# Altium schematic sheet RP2040.SchDoc(RP2040)
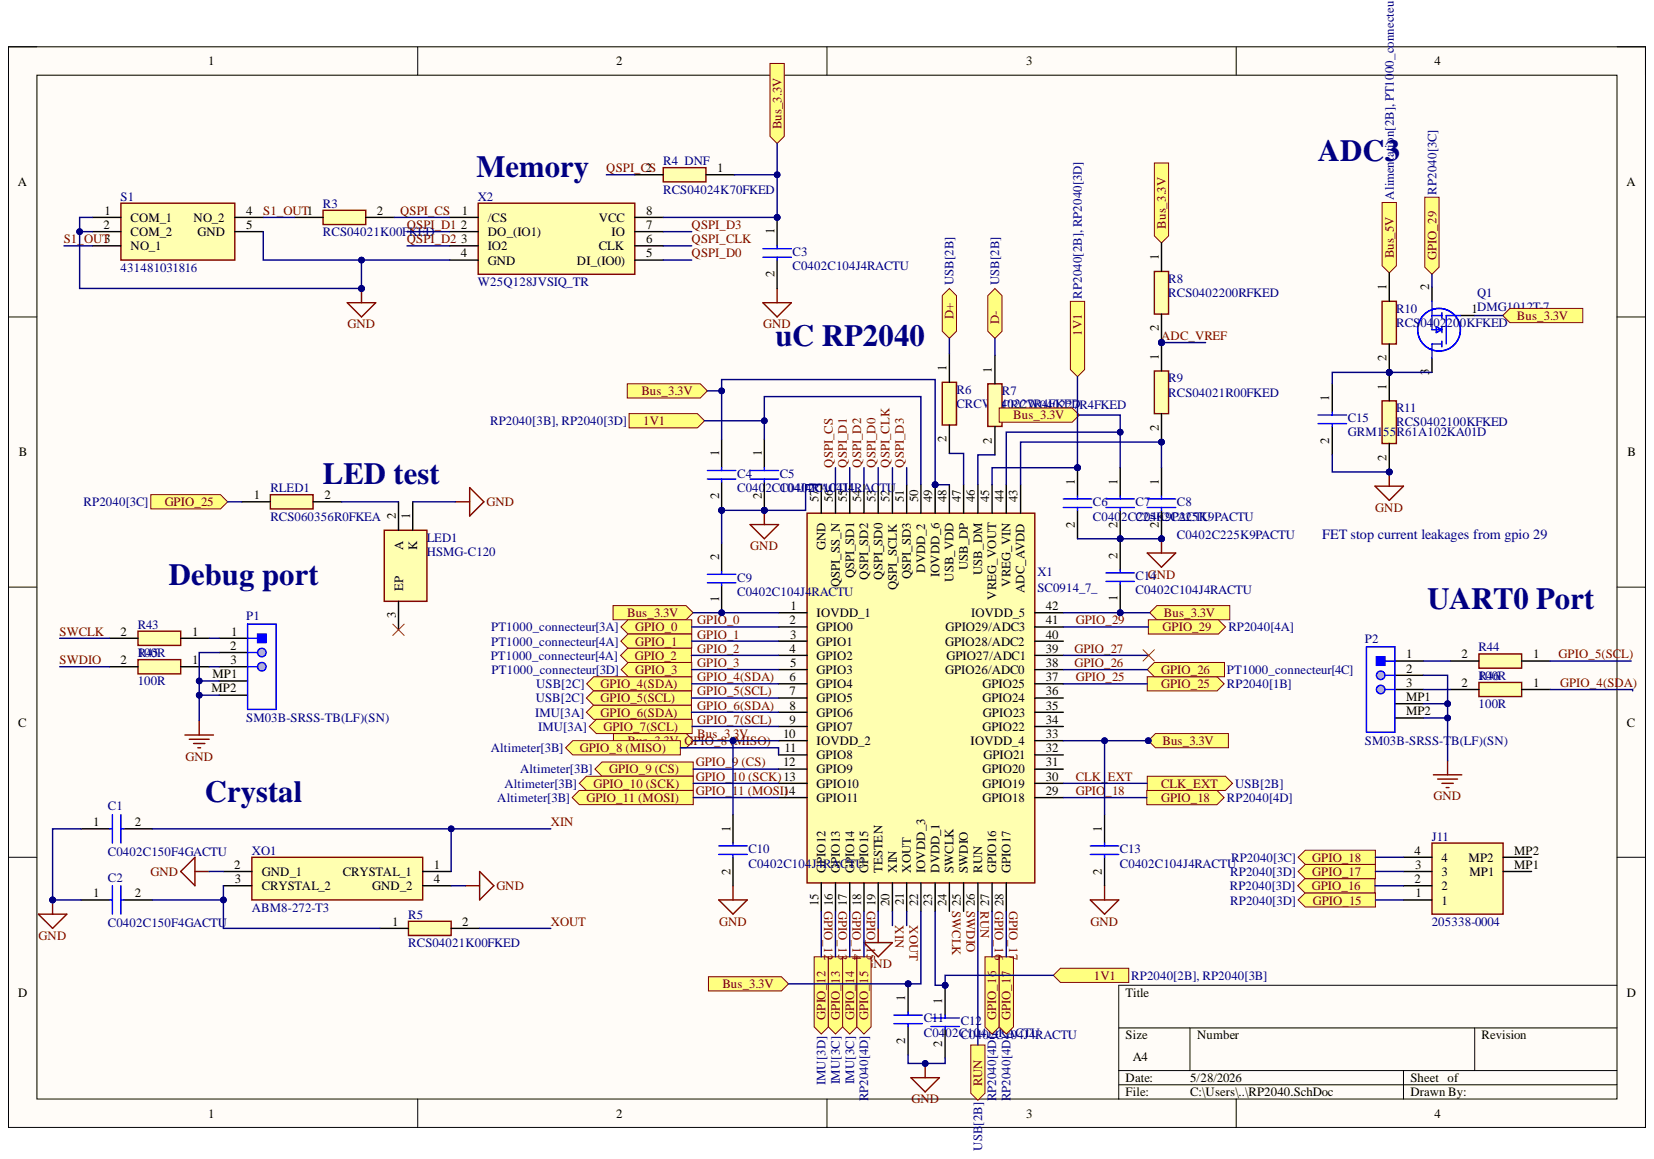
[diagram p0: RP2040.SchDoc]

## Parts
C1  C0402C150F4GACTU  [C0402C104J4RACTU]
C2  C0402C150F4GACTU  [C0402C104J4RACTU]
C3  C0402C104J4RACTU  [C0402C104J4RACTU]
C4  C0402C104J4RACTU  [C0402C104J4RACTU]
C5  C0402C104J4RACTU  [C0402C104J4RACTU]
C6  C0402C225K9PACTU  [C0402C104J4RACTU]
C7  C0402C225K9PACTU  [C0402C104J4RACTU]
C8  C0402C225K9PACTU  [C0402C104J4RACTU]
C9  C0402C104J4RACTU  [C0402C104J4RACTU]
C10  C0402C104J4RACTU  [C0402C104J4RACTU]
C11  C0402C104J4RACTU  [C0402C104J4RACTU]
C12  C0402C104J4RACTU  [C0402C104J4RACTU]
C13  C0402C104J4RACTU  [C0402C104J4RACTU]
C14  C0402C104J4RACTU  [C0402C104J4RACTU]
C15  GRM155R61A102KA01D  [CAPC1005X70N]
J11  205338-0004  [2053380004]
LED1  HSMG-C120  [HSMGC120]
P1  SM03B-SRSS-TB(LF)(SN)  [JST-SM03B-SRSS-TB_V]
P2  SM03B-SRSS-TB(LF)(SN)  [JST-SM03B-SRSS-TB_V]
Q1  DMG1012T-7  [SOT50P160X90-3N]
R3  RCS04021K00FKED  [RCS0402_E3]
R4  RCS04024K70FKED  [RCS0402_E3]
R5  RCS04021K00FKED  [RCS0402_E3]
R6  CRCW040227R4FKED  [RESC1005X40N]
R7  CRCW040227R4FKED  [RESC1005X40N]
R8  RCS0402200RFKED  [RCS0402_E3]
R9  RCS04021R00FKED  [RCS0402_E3]
R10  RCS0402200KFKED  [RCS0402_E3]
R11  RCS0402100KFKED  [RCS0402_E3]
R43  100R  [RCS0402_E3]
R44  100R  [RCS0402_E3]
R45  100R  [RCS0402_E3]
R46  100R  [RCS0402_E3]
RLED1  RCS060356R0FKEA  [RCS0603_E3]
S1  431481031816  [431481031816]
X1  SC0914_7_  [QFN40P700X700X90-57N-D]
X2  W25Q128JVSIQ_TR  [SOIC127P780X195-8N]
XO1  ABM8-272-T3  [ABM8272T3]

## Ports
1V1, Bus_3.3V, Bus_5V, CLK_EXT, D+, D-, GPIO_0, GPIO_1, GPIO_2, GPIO_3, GPIO_4(SDA), GPIO_5(SCL), GPIO_6(SDA), GPIO_7(SCL), GPIO_8 (MISO), GPIO_9 (CS), GPIO_10 (SCK), GPIO_11 (MOSI), GPIO_12, GPIO_13, GPIO_14, GPIO_15, GPIO_16, GPIO_17, GPIO_18, GPIO_25, GPIO_26, GPIO_29, RUN

## Nets
GND: C1.1 C2.1 C3.2 C4.2 C5.2 C6.2 C7.2 C8.2 C9.2 C10.2 C11.2 C12.2 C13.2 C14.2 C15.2 LED1.1 P1.2 P1.MP1 P1.MP2 P2.2 P2.MP1 P2.MP2 R11.2 S1.1(COM_1) S1.2(COM_2) S1.5(GND) X1.19(TESTEN) X1.57(GND) X2.4(GND) XO1.2(GND_1) XO1.4(GND_2)
1V1: C5.1 C6.1 C12.1 X1.23(DVDD_1) X1.45(VREG_VOUT) X1.50(DVDD_2) ; port 1V1
ADC_VREF: R8.2 R9.1
Bus_3.3V: C3.1 C4.1 C7.1 C9.1 C10.1 C11.1 C13.1 C14.1 Q1.1 R4.1 R8.1 X1.1(IOVDD_1) X1.10(IOVDD_2) X1.22(IOVDD_3) X1.33(IOVDD_4) X1.42(IOVDD_5) X1.44(VREG_VIN) X1.48(USB_VDD) X1.49(IOVDD_6) X2.8(VCC) ; port Bus_3.3V
Bus_5V: R10.1 ; port Bus_5V
CLK_EXT: X1.30(GPIO19) ; port CLK_EXT
GPIO_0: X1.2(GPIO0) ; port GPIO_0
GPIO_1: X1.3(GPIO1) ; port GPIO_1
GPIO_2: X1.4(GPIO2) ; port GPIO_2
GPIO_3: X1.5(GPIO3) ; port GPIO_3
GPIO_4(SDA): R46.1 X1.6(GPIO4) ; port GPIO_4(SDA)
GPIO_5(SCL): R44.1 X1.7(GPIO5) ; port GPIO_5(SCL)
GPIO_6(SDA): X1.8(GPIO6) ; port GPIO_6(SDA)
GPIO_7(SCL): X1.9(GPIO7) ; port GPIO_7(SCL)
GPIO_8 (MISO): X1.11(GPIO8) ; port GPIO_8 (MISO)
GPIO_9 (CS): X1.12(GPIO9) ; port GPIO_9 (CS)
GPIO_10 (SCK): X1.13(GPIO10) ; port GPIO_10 (SCK)
GPIO_11 (MOSI): X1.14(GPIO11) ; port GPIO_11 (MOSI)
GPIO_12: X1.15(GPIO12) ; port GPIO_12
GPIO_13: X1.16(GPIO13) ; port GPIO_13
GPIO_14: X1.17(GPIO14) ; port GPIO_14
GPIO_15: J11.1 X1.18(GPIO15) ; port GPIO_15
GPIO_16: J11.2 X1.27(GPIO16) ; port GPIO_16
GPIO_17: J11.3 X1.28(GPIO17) ; port GPIO_17
GPIO_18: J11.4 X1.29(GPIO18) ; port GPIO_18
GPIO_25: RLED1.1 X1.37(GPIO25) ; port GPIO_25
GPIO_26: X1.38(GPIO26/ADC0) ; port GPIO_26
GPIO_27: X1.39(GPIO27/ADC1)
GPIO_29: Q1.2 X1.41(GPIO29/ADC3) ; port GPIO_29
QSPI_CLK: X1.52(QSPI_SCLK) X2.6(CLK)
QSPI_CS: R3.2 R4.2 X1.56(QSPI_SS_N) X2.1(/CS)
QSPI_D0: X1.53(QSPI_SD0) X2.5(DI_(IO0))
QSPI_D1: X1.55(QSPI_SD1) X2.2(DO_(IO1))
QSPI_D2: X1.54(QSPI_SD2) X2.3(IO2)
QSPI_D3: X1.51(QSPI_SD3) X2.7(IO)
RUN: X1.26(RUN) ; port RUN
S1_OUT: R3.1 S1.3(NO_1) S1.4(NO_2)
SWCLK: R43.2 X1.24(SWCLK)
SWDIO: R45.2 X1.25(SWDIO)
XIN: C1.2 X1.20(XIN) XO1.1(CRYSTAL_1)
XOUT: R5.2 X1.21(XOUT)
NetC2_2: C2.2 R5.1 XO1.3(CRYSTAL_2)
NetC8_1: C8.1 R9.2 X1.43(ADC_AVDD)
NetC15_1: C15.1 Q1.3 R10.2 R11.1
NetLED1_2: LED1.2 RLED1.2
NetP1_1: P1.1 R43.1
NetP1_3: P1.3 R45.1
NetP2_1: P2.1 R44.2
NetP2_3: P2.3 R46.2
NetR6_1: R6.1
NetR6_2: R6.2 X1.47(USB_DP)
NetR7_1: R7.1
NetR7_2: R7.2 X1.46(USB_DM)

Not connected: J11 MP1 MP2; LED1 3; X1 31(GPIO20) 32(GPIO21) 34(GPIO22) 35(GPIO23) 36(GPIO24) 40(GPIO28/ADC2)

## Library data of the parts
C1 C2 = C0402C150F4GACTU: Capacitor; Manufacturer_Name: KEMET; Component Kind: Standard; Datasheet Link: https://content.kemet.com/datasheets/KEM_C1002_X7R_SMD.pdf; Height: 0.55mm; Manufacturer_Part_Number: C0402C150F4GACTU; Library Name: ADSC_V2.IntLib
C3-C14 = C0402C104J4RACTU: Condensateur 100nF; Manufacturer_Name: KEMET; Arrow Part Number: C0402C104J4RACTU; Arrow Price/Stock: https://www.arrow.com/en/products/c0402c104j4ractu/kemet-corporation?utm_currency=USD&region=nac; Component Kind: Standard; Datasheet Link: https://content.kemet.com/datasheets/KEM_C1002_X7R_SMD.pdf; Height: 0.55mm; Manufacturer_Part_Number: C0402C104J4RACTU; Mouser Part Number: 80-C0402C104J4R; Mouser Price/Stock: https://www.mouser.co.uk/ProductDetail/KEMET/C0402C104J4RACTU?qs=hOC58ESJ5%2FTi6zdFDTVLPg%3D%3D; Library Name: ADSC_V2.IntLib
C15 = GRM155R61A102KA01D: Capacitor; Manufacturer_Name: Murata Electronics; Arrow Part Number: GRM155R61A102KA01D; Arrow Price/Stock: https://www.arrow.com/en/products/grm155r61a102ka01d/murata-manufacturing?region=nac; Component Kind: Standard; Datasheet Link: https://search.murata.co.jp/Ceramy/image/img/A01X/G101/ENG/GRM155R61A102KA01-01A.pdf; Height: 0.7mm; Manufacturer_Part_Number: GRM155R61A102KA01D; Mouser Part Number: 81-GRM155R61A102KA1D; Mouser Price/Stock: https://www.mouser.co.uk/ProductDetail/Murata-Electronics/GRM155R61A102KA01D?qs=y02iAgv9n635dgph15ru9A%3D%3D; Library Name: ADSC_V2.IntLib
J11 = 205338-0004: Connector; Manufacturer_Name: Molex; Component Kind: Standard; Datasheet Link: https://www.molex.com/pdm_docs/sd/2053380004_sd.pdf; Height: 2.8mm; Manufacturer_Part_Number: 205338-0004; Mouser Part Number: 538-205338-0004; Mouser Price/Stock: https://www.mouser.co.uk/ProductDetail/Molex/205338-0004?qs=GedFDFLaBXGldxOQTm%2FukQ%3D%3D; Library Name: ADSC_V2.IntLib
LED1 = HSMG-C120: LED; Manufacturer_Name: Avago Technologies; Component Kind: Standard; Datasheet Link: https://docs.broadcom.com/docs/AV02-0551EN; Height: 0.7mm; Manufacturer_Part_Number: HSMG-C120; Mouser Part Number: 630-HSMG-C120; Mouser Price/Stock: https://www.mouser.co.uk/ProductDetail/Broadcom-Avago/HSMG-C120?qs=YDL0qNrpDT6Egvnvl3TReA%3D%3D; Library Name: ADSC_V2.IntLib
P1 P2 = CMP-2000-07077-1: Male Header, Pitch 1 mm, 1 x 3 Position, Height 2.9 mm, -25 to 85 degC, RoHS, Tape and Reel; Manufacturer: JST; No of Positions: 3; Pitch: 1mm; Style/Orientation: RightAngleHeader/Plug; Component Kind: Standard; Datasheet URL: https://datasheet.ciiva.com/26894/getdatasheetpartid-708885-26894671.pdf; Datasheet Version: 11/2010; Library Name: Altium Content Vault; Manufacturer URL: http://www.jst.com/home2.html; Mounting Technology: SurfaceMount; Package Description: Male Header, Pitch 1 mm, 1 x 3 Position, Height 2.9 mm; Package Reference: SM03B-SRSS-TB; Termination: Crimp; Type: ShroudedHeader
Q1 = DMG1012T-7: MOSFET (N-Channel); Manufacturer_Name: Diodes Incorporated; Arrow Part Number: DMG1012T-7; Arrow Price/Stock: https://www.arrow.com/en/products/dmg1012t-7/diodes-incorporated?utm_currency=USD&region=nac; Component Kind: Standard; Datasheet Link: https://www.diodes.com//assets/Datasheets/DMG1012T.pdf; Height: 0.9mm; Manufacturer_Part_Number: DMG1012T-7; Mouser Part Number: 621-DMG1012T-7; Mouser Price/Stock: https://www.mouser.co.uk/ProductDetail/Diodes-Incorporated/DMG1012T-7?qs=vIZ3oKQCLxoEnfyeB0HcGQ%3D%3D; Library Name: ADSC_V2.IntLib
R3 R5 = RCS04021K00FKED: Resistor; Manufacturer_Name: Vishay; Component Kind: Standard; Datasheet Link: https://www.vishay.com/docs/20065/rcse3.pdf; Manufacturer_Part_Number: RCS04021K00FKED; Mouser Part Number: 71-RCS04021K00FKED; Mouser Price/Stock: https://www.mouser.com/Search/Refine.aspx?Keyword=71-RCS04021K00FKED; Library Name: ADSC_V2.IntLib
R4 R43-R46 = RCS04024K70FKED: Résistance 4.7kohm; Manufacturer_Name: Vishay; Arrow Part Number: RCS04024K70FKED; Arrow Price/Stock: https://www.arrow.com/en/products/rcs04024k70fked/vishay?utm_currency=USD&region=nac; Component Kind: Standard; Datasheet Link: https://www.vishay.com/docs/20065/rcse3.pdf; Manufacturer_Part_Number: RCS04024K70FKED; Mouser Part Number: 71-RCS04024K70FKED; Mouser Price/Stock: https://www.mouser.co.uk/ProductDetail/Vishay-Dale/RCS04024K70FKED?qs=NKmfXavxMaw%252Bu6VUO3JuMQ%3D%3D; Library Name: ADSC_V2.IntLib
R6 R7 = CRCW040227R4FKED: Resistor; Manufacturer_Name: Vishay; Arrow Part Number: CRCW040227R4FKED; Arrow Price/Stock: https://www.arrow.com/en/products/crcw040227r4fked/vishay; Component Kind: Standard; Datasheet Link: http://www.vishay.com/docs/20035/dcrcwe3.pdf; Height: 0.4mm; Manufacturer_Part_Number: CRCW040227R4FKED; Mouser Part Number: 71-CRCW0402-27.4-E3; Mouser Price/Stock: https://www.mouser.co.uk/ProductDetail/Vishay-Dale/CRCW040227R4FKED?qs=hD42E%2F8yzjpHLEpc7c%2FFRA%3D%3D; Library Name: ADSC_V2.IntLib
R8 = RCS0402200RFKED: Resistor; Manufacturer_Name: Vishay; Component Kind: Standard; Datasheet Link: https://www.vishay.com/docs/20065/rcse3.pdf; Manufacturer_Part_Number: RCS0402200RFKED; Mouser Part Number: 71-RCS0402200RFKED; Mouser Price/Stock: https://www.mouser.com/Search/Refine.aspx?Keyword=71-RCS0402200RFKED; Library Name: ADSC_V2.IntLib
R9 = RCS04021R00FKED: Resistor; Manufacturer_Name: Vishay; Arrow Part Number: RCS04021R00FKED; Arrow Price/Stock: https://www.arrow.com/en/products/rcs04021r00fked/vishay?region=nac; Component Kind: Standard; Datasheet Link: https://www.vishay.com/docs/20065/rcse3.pdf; Manufacturer_Part_Number: RCS04021R00FKED; Mouser Part Number: 71-RCS04021R00FKED; Mouser Price/Stock: https://www.mouser.co.uk/ProductDetail/Vishay-Dale/RCS04021R00FKED?qs=NKmfXavxMawiQee1nWtrTg%3D%3D; Library Name: ADSC_V2.IntLib
R10 = RCS0402200KFKED: Resistor; Manufacturer_Name: Vishay; Arrow Part Number: RCS0402200KFKED; Arrow Price/Stock: https://www.arrow.com/en/products/rcs0402200kfked/vishay?region=nac; Component Kind: Standard; Datasheet Link: https://www.vishay.com/docs/20065/rcse3.pdf; Manufacturer_Part_Number: RCS0402200KFKED; Mouser Part Number: 71-RCS0402200KFKED; Mouser Price/Stock: https://www.mouser.co.uk/ProductDetail/Vishay-Dale/RCS0402200KFKED?qs=yDQnu9hAfI%2FealnERnvG6g%3D%3D; Library Name: ADSC_V2.IntLib
R11 = RCS0402100KFKED: Resistor; Manufacturer_Name: Vishay; Component Kind: Standard; Datasheet Link: https://www.vishay.com/docs/20065/rcse3.pdf; Manufacturer_Part_Number: RCS0402100KFKED; Library Name: ADSC_V2.IntLib
RLED1 = RCS060356R0FKEA: Resistor; Manufacturer_Name: Vishay; Component Kind: Standard; Datasheet Link: https://www.vishay.com/docs/20065/rcse3.pdf; Manufacturer_Part_Number: RCS060356R0FKEA; Mouser Part Number: 71-RCS060356R0FKEA; Mouser Price/Stock: https://www.mouser.co.uk/ProductDetail/Vishay-Dale/RCS060356R0FKEA?qs=HalnxurKHBvQZkWZeIuDjw%3D%3D; Library Name: ADSC_V2.IntLib
S1 = 431481031816: Switch; Manufacturer_Name: Wurth Elektronik; Component Kind: Standard; Datasheet Link: https://www.we-online.de/katalog/datasheet/431481031816.pdf; Height: 3.25mm; Manufacturer_Part_Number: 431481031816; Mouser Part Number: 710-431481031816; Mouser Price/Stock: https://www.mouser.co.uk/ProductDetail/Wurth-Elektronik/431481031816?qs=wr8lucFkNMXOtwRx5ez0kw%3D%3D; Library Name: ADSC_V2.IntLib
X1 = SC0914_7_: Connector; Manufacturer_Name: RASPBERRY-PI; Manufacturer_Part_Number: SC0914(7); Component Kind: Standard; Datasheet Link: https://www.mouser.com/datasheet/2/635/rp2040_datasheet-3048960.pdf; Height: 0.9mm; Mouser Part Number: 358-SC09147; Mouser Price/Stock: https://www.mouser.co.uk/ProductDetail/Raspberry-Pi/SC09147?qs=T%252BzbugeAwjhSpdbCB4ve%252Bg%3D%3D; Library Name: ADSC_V2.IntLib
X2 = W25Q128JVSIQ_TR: Inductor; Manufacturer_Name: Winbond; Manufacturer_Part_Number: W25Q128JVSIQ TR; Arrow Part Number: W25Q128JVSIQ TR; Arrow Price/Stock: https://www.arrow.com/en/products/w25q128jvsiqtr/winbond-electronics?utm_currency=USD&region=nac; Component Kind: Standard; Datasheet Link: http://www.winbond.com/resource-files/w25q128jv%20spi%20revc%2011162016.pdf; Height: 1.95mm; Mouser Part Number: 454-W25Q128JVSIQTR; Mouser Price/Stock: https://www.mouser.co.uk/ProductDetail/Winbond/W25Q128JVSIQ-TR?qs=qSfuJ%252Bfl%2Fd7vTyLA9kNdGw%3D%3D; Library Name: ADSC_V2.IntLib
XO1 = ABM8-272-T3: Crystal or Oscillator; Manufacturer_Name: ABRACON; Component Kind: Standard; Datasheet Link: https://abracon.com/datasheets/ABM8-272-T3.pdf; Height: 0.8mm; Manufacturer_Part_Number: ABM8-272-T3; Mouser Part Number: 815-ABM8-272-T3; Mouser Price/Stock: https://www.mouser.co.uk/ProductDetail/ABRACON/ABM8-272-T3?qs=QpmGXVUTftEj6miOiJBMxQ%3D%3D; Library Name: ADSC_V2.IntLib
